# Altium schematic sheet LTE,GPS.SchDoc
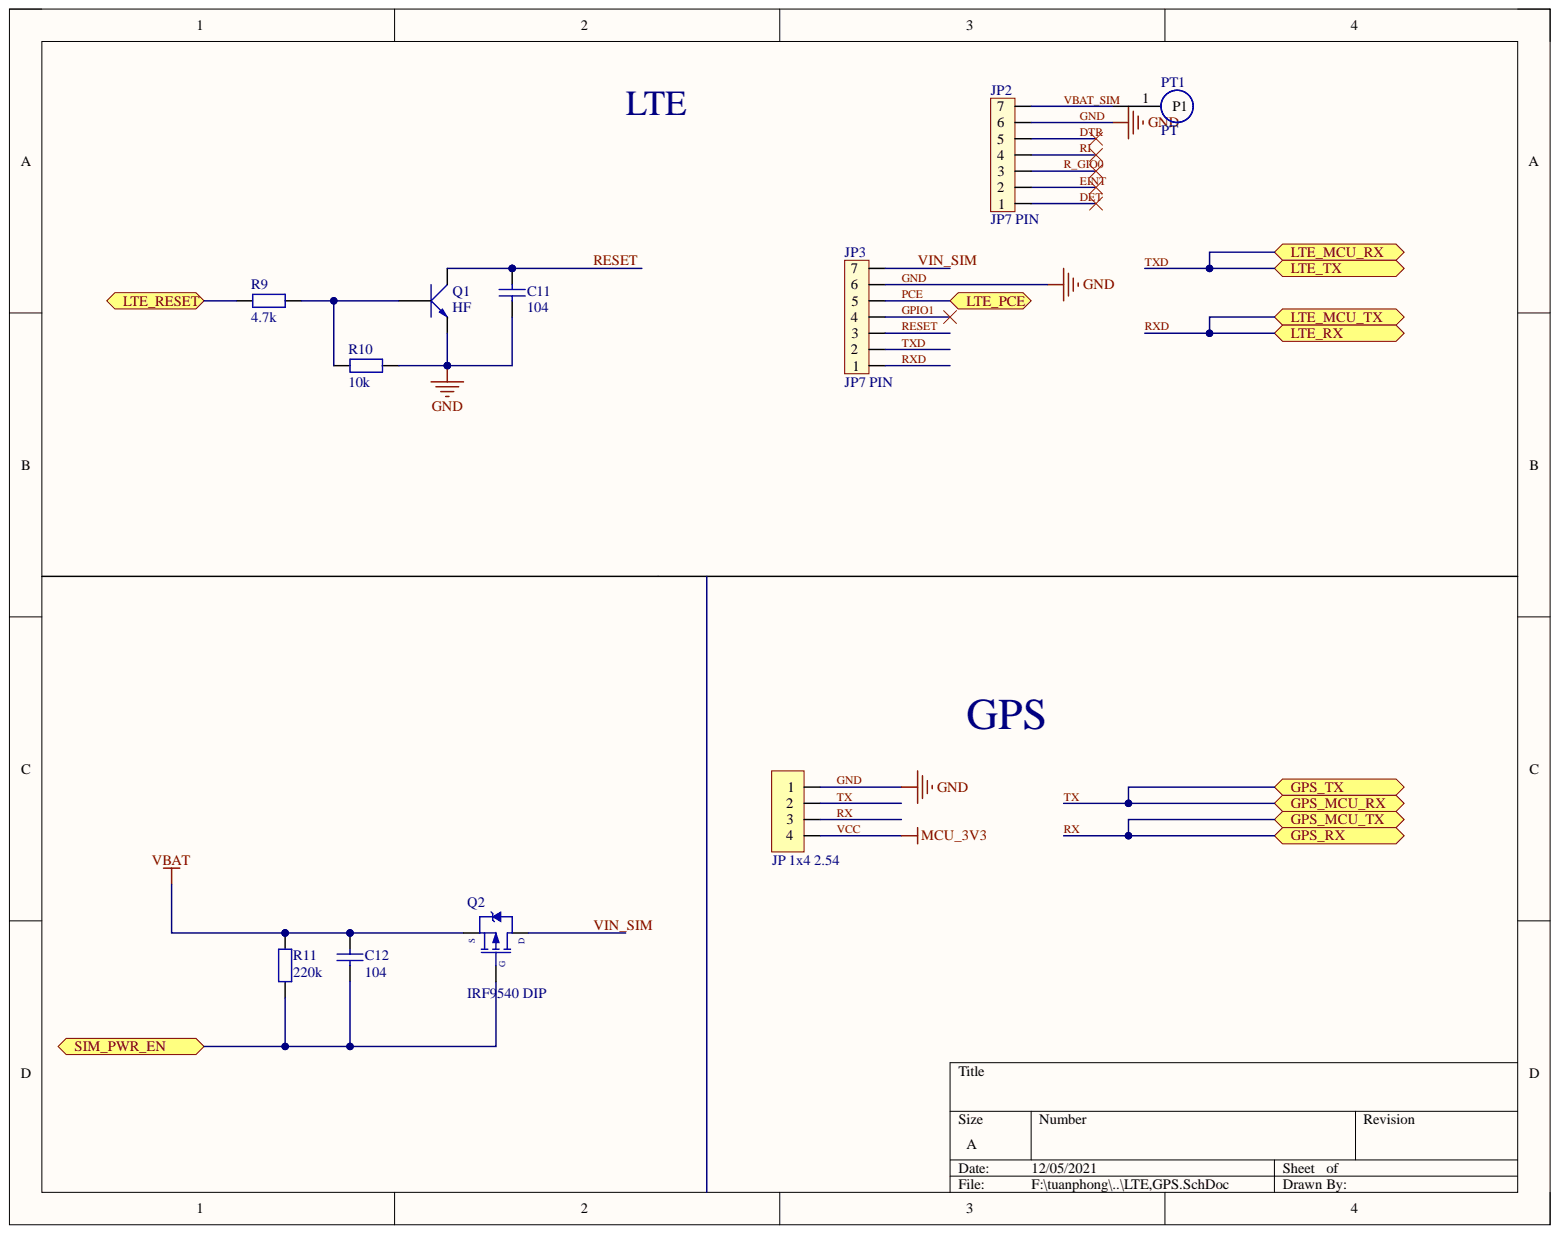
[diagram p0: LTE,GPS.SchDoc]

## Parts
C11  104  [tu 0805]
C12  104  [tu 0805]
JP2  JP7 PIN  value JP7  [JP7]
JP3  JP7 PIN  value JP7  [JP7]
JP4  JP 1x4 2.54  [JP 1x4 DC]
PT1  PT  [Test pont]  (no BOM)
Q1  HF  [SOT23]
Q2  IRF9540 DIP  [DPAK254P1511X469-3N]
R9  4.7k  [tro smd 0805]
R10  10k  [tro smd 0805]
R11  220k  [tro smd 0805]

## Ports
GPS_MCU_RX, GPS_MCU_TX, GPS_RX, GPS_TX, LTE_MCU_RX, LTE_MCU_TX, LTE_PCE, LTE_RESET, LTE_RX, LTE_TX, SIM_PWR_EN

## Nets
VBAT: C12.2 Q2.3 R11.2
DET: JP2.1
DTR: JP2.5
EINT: JP2.2
GND: C11.1 JP2.6 JP3.6 JP4.1 Q1.2 R10.2
GPIO1: JP3.4
LTE_RESET: R9.1 ; port LTE_RESET
PCE: JP3.5 ; port LTE_PCE
R_GIO0: JP2.3
RESET: C11.2 JP3.3 Q1.3
RI: JP2.4
RX: JP4.3 ; port GPS_MCU_TX, port GPS_RX
RXD: JP3.1 ; port LTE_MCU_TX, port LTE_RX
SIM_PWR_EN: C12.1 Q2.1 R11.1 ; port SIM_PWR_EN
TX: JP4.2 ; port GPS_MCU_RX, port GPS_TX
TXD: JP3.2 ; port LTE_MCU_RX, port LTE_TX
VBAT_SIM: JP2.7 PT1.1
VCC: JP4.4
VIN_SIM: JP3.7 Q2.2
NetQ1_1: Q1.1 R9.2 R10.1

## Library data of the parts
C11 C12 = Tu 0805 104 50V 10%: Dán; Don_Vi: Con; Ma_Hang: MH004551; So_Chan: 2; Ten_Linh_Kien: Tụ 0805 104-100nF 10% 50V; Chung_Loai: Dán; Component Kind: Standard; Library Name: Altium_Lib_by_KhueNguyenCreator.IntLib
JP2 JP3 = JP7: Component Kind: Standard; Description: JP7 PIN; Library Name: syhaunguyen.IntLib
JP4 = JP 1x4 2.54 Duc Cong: Cắm; Don_Vi: Con; Ma_Hang: MH000904; Ten_Linh_Kien: Jump Đơn Đực 2.54MM 1x40P Cong Đứng; Chung_Loai: Cắm; Component Kind: Standard; Library Name: Altium_Lib_by_KhueNguyenCreator.IntLib
PT1 = test pont: Library Name: Test pont.SchLib
Q1 = C1815 HF SMD: NPN transistor; Value: C9014; Component Kind: Standard; Library Name: Altium_Lib_by_KhueNguyenCreator.IntLib
Q2 = IRF9540 DIP: P-Channel Power MOSFET; Note: Pin 2 is electrically connected to pin 4.; Component Kind: Standard; ComponentLink1Description: Manufacturer Link; ComponentLink1URL: http://www.irf.com/; ComponentLink2Description: Datasheet; ComponentLink2URL: http://www.irf.com/product-info/datasheets/data/irf9540.pdf; DatasheetVersion: PD-9.421C; LatestRevisionDate: 17-Jul-2002; LatestRevisionNote: Re-released for DXP Platform.; PackageDescription: TO, Thru-Hole, Vertical, Heatsink Mounted; 3 In-Line Leads; Pitch 2.54 mm; PackageReference: TO-220AB; PackageVersion: Feb-1997; Published: 8-Jun-2000; Library Name: Altium_Lib_by_KhueNguyenCreator.IntLib; Publisher: Altium Limited
R9 = Tro 0805 5% 4.7k: Dán; Don_Vi: Con; Ma_Hang: MH005307; So_Chan: 2; Ten_Linh_Kien: Trở 0805 5% 4.7k; Chung_Loai: Dán; Component Kind: Standard; Library Name: Altium_Lib_by_KhueNguyenCreator.IntLib
R10 = Tro 0805 5% 10k: Dán; Don_Vi: Con; Ma_Hang: MH005239; So_Chan: 2; Ten_Linh_Kien: Trở 0805 5% 10k; Chung_Loai: Dán; Component Kind: Standard; Library Name: Altium_Lib_by_KhueNguyenCreator.IntLib
R11 = Tro 0805 5% 220k: Dán; Don_Vi: Con; Ma_Hang: MH005258; So_Chan: 2; Ten_Linh_Kien: Trở 0805 5% 220k; Chung_Loai: Dán; Component Kind: Standard; Library Name: Altium_Lib_by_KhueNguyenCreator.IntLib
